# Altium schematic sheet 06 ETH部分.SchDoc("06 ETH部分")
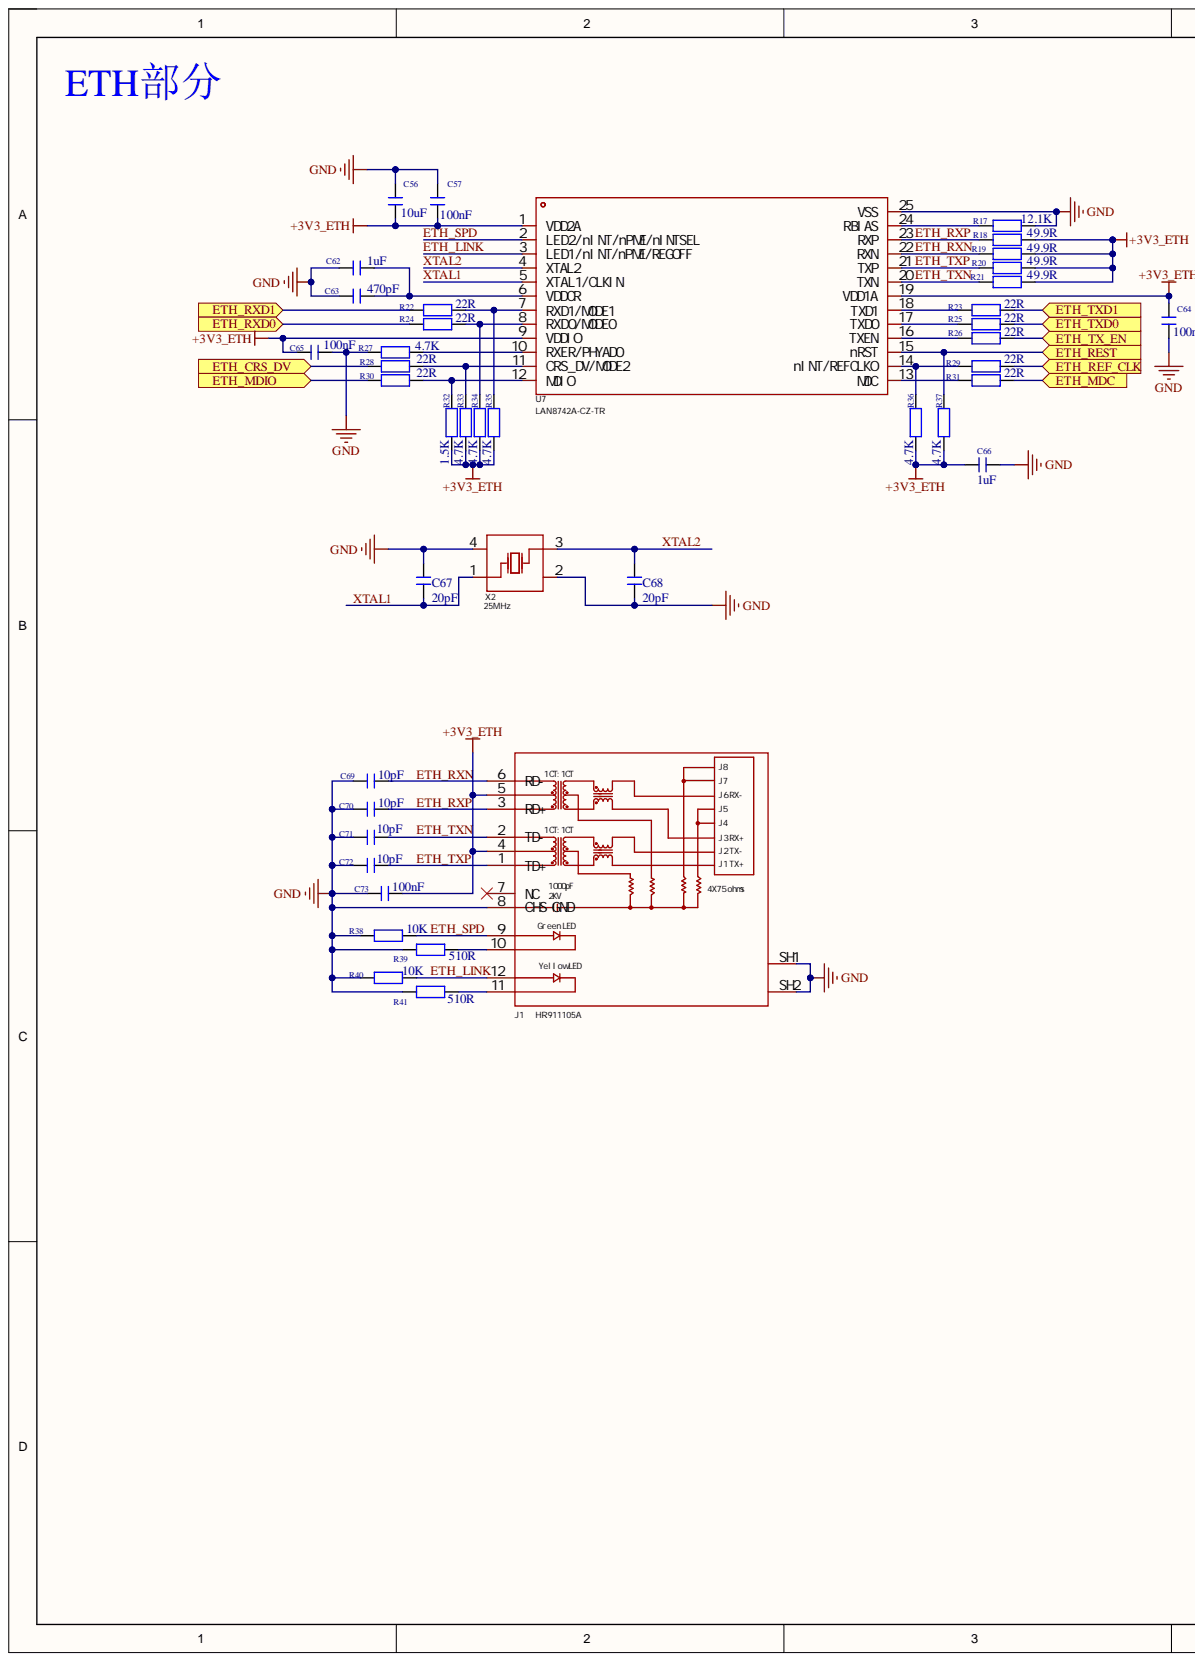
[diagram p0: 06 ETH部分.SchDoc, left]
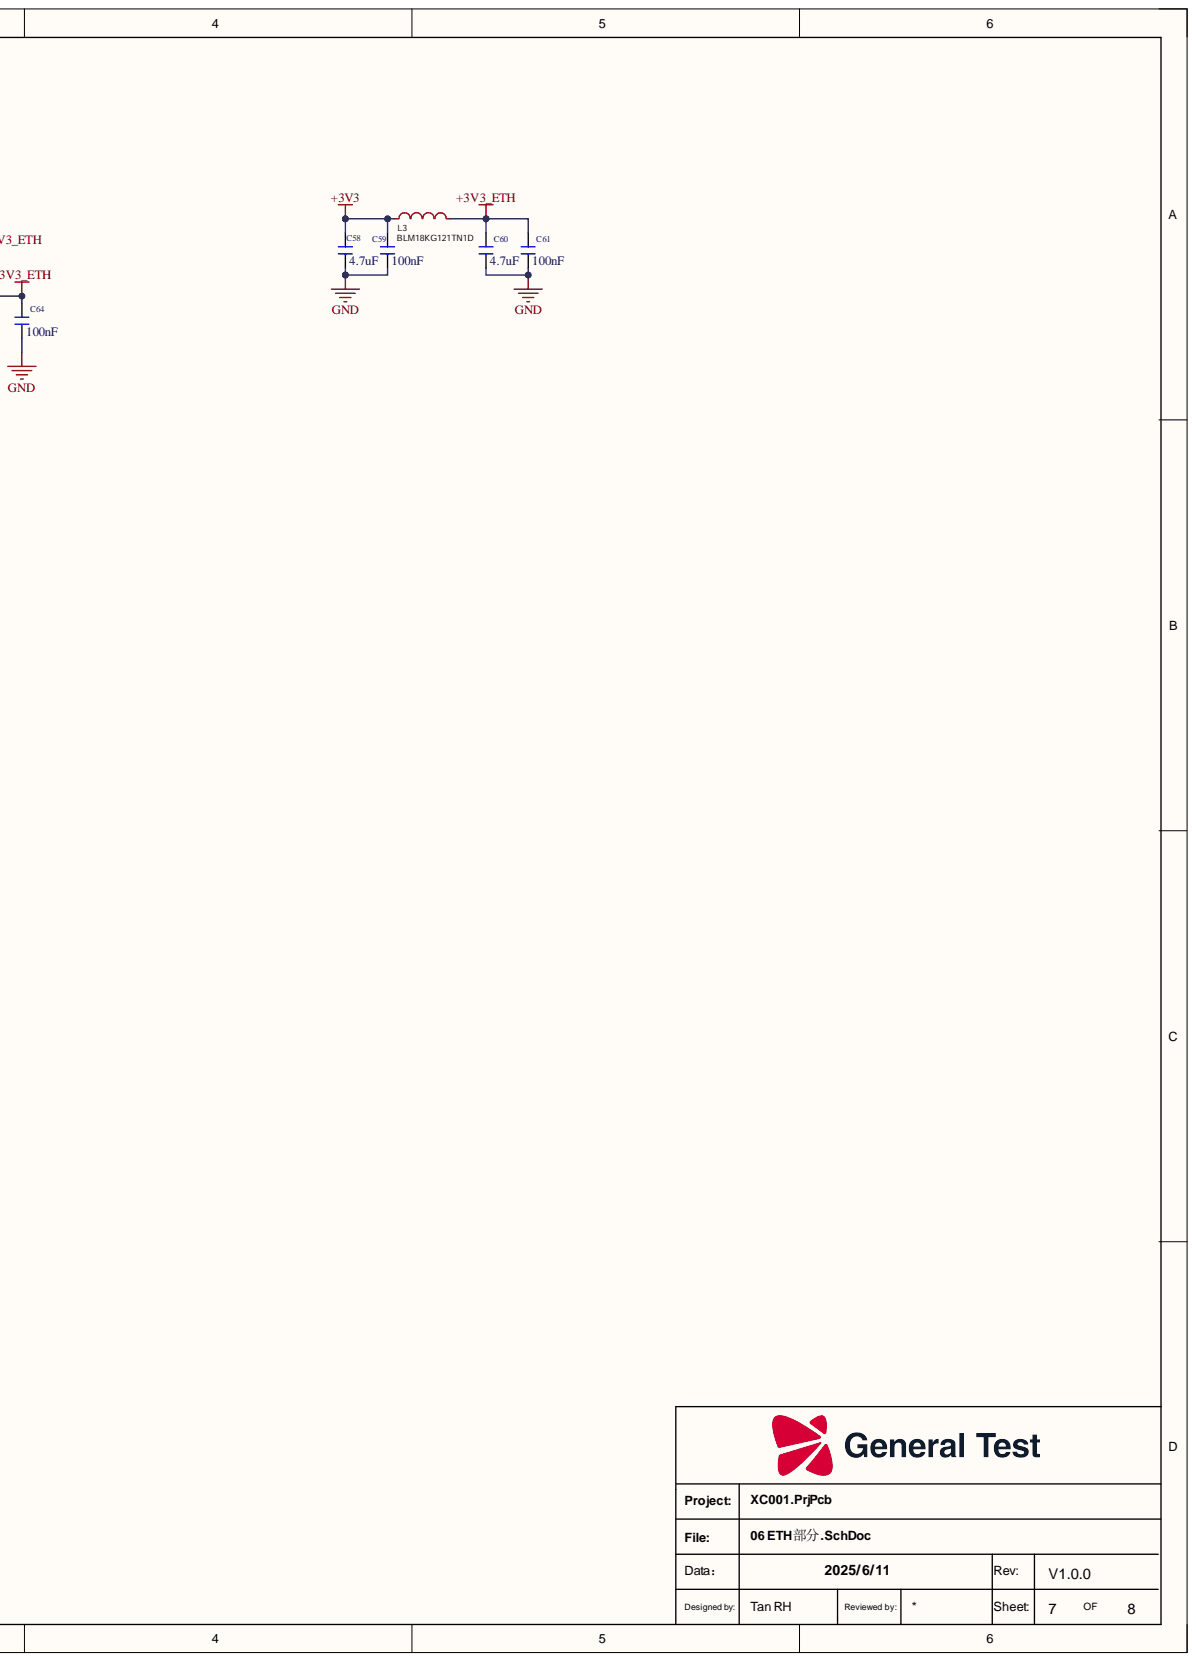
[diagram p1: 06 ETH部分.SchDoc, right]

## Parts
C56  10uF  [C0402_L]
C57  100nF  [C0402_L]
C58  4.7uF  [C0402_L]
C59  100nF  [C0402_L]
C60  4.7uF  [C0402_L]
C61  100nF  [C0402_L]
C62  1uF  [C0402_L]
C63  470pF  [C0402_L]
C64  100nF  [C0402_L]
C65  100nF  [C0402_L]
C66  1uF  [C0402_L]
C67  20pF  [C0402_L]
C68  20pF  [C0402_L]
C69  10pF  [C0402_L]
C70  10pF  [C0402_L]
C71  10pF  [C0402_L]
C72  10pF  [C0402_L]
C73  100nF  [C0603_L]
J1  HR911105A  [RJ45-TH_HR911105A]
L3  BLM18KG121TN1D  [BLM18EG101TH1D]
R17  12.1K  [R0402_L]
R18  49.9R  [R0402_L]
R19  49.9R  [R0402_L]
R20  49.9R  [R0402_L]
R21  49.9R  [R0402_L]
R22  22R  [R0402_L]
R23  22R  [R0402_L]
R24  22R  [R0402_L]
R25  22R  [R0402_L]
R26  22R  [R0402_L]
R27  4.7K  [R0402_L]
R28  22R  [R0402_L]
R29  22R  [R0402_L]
R30  22R  [R0402_L]
R31  22R  [R0402_L]
R32  1.5K  [R0402_L]
R33  4.7K  [R0402_L]
R34  4.7K  [R0402_L]
R35  4.7K  [R0402_L]
R36  4.7K  [R0402_L]
R37  4.7K  [R0402_L]
R38  10K  [R0402_L]
R39  510R  [R0402_L]
R40  10K  [R0402_L]
R41  510R  [R0402_L]
U7  LAN8742A-CZ-TR  [QFN-24_L4.0-W4.0-P0.50-TL-EP2.5]
X2  25MHz  [CRYSTAL-SMD_4P-L3.2-W2.5-BL-2]

## Ports
ETH_CRS_DV, ETH_MDC, ETH_MDIO, ETH_REF_CLK, ETH_REST, ETH_RXD0, ETH_RXD1, ETH_TX_EN, ETH_TXD0, ETH_TXD1

## Nets
+3V3: C58.2 C59.2 L3.1
+3V3_ETH: C56.2 C57.2 C60.2 C61.2 C64.2 C65.1 C66.1 C73.1 J1.4 J1.5 L3.2 R18.2 R19.2 R20.2 R21.2 R32.1 R33.1 R34.1 R35.1 R36.1 R37.1 U7.1(VDD2A) U7.9(VDDIO) U7.19(VDD1A)
GND: C56.1 C57.1 C58.1 C59.1 C60.1 C61.1 C62.1 C63.1 C64.1 C65.2 C66.2 C67.1 C68.2 C69.2 C70.2 C71.2 C72.2 C73.2 J1.8(CHS_GND) J1.SH1 J1.SH2 R17.2 R27.1 R38.1 R39.1 R40.1 R41.1 U7.25(VSS) X2.2 X2.4
ETH_CRS_DV: R28.1 ; port ETH_CRS_DV
ETH_LINK: J1.12 R40.2 U7.3(LED1/nINT/nPME/REGOFF)
ETH_MDC: R31.2 ; port ETH_MDC
ETH_MDIO: R30.1 ; port ETH_MDIO
ETH_REF_CLK: R29.2 ; port ETH_REF_CLK
ETH_REST: R37.2 U7.15(nRST) ; port ETH_REST
ETH_RXD0: R24.1 ; port ETH_RXD0
ETH_RXD1: R22.1 ; port ETH_RXD1
ETH_RXN: C69.1 J1.6(RD-) R19.1 U7.22(RXN)
ETH_RXP: C70.1 J1.3(RD+) R18.1 U7.23(RXP)
ETH_SPD: J1.9 R38.2 U7.2(LED2/nINT/nPME/nINTSEL)
ETH_TX_EN: R26.2 ; port ETH_TX_EN
ETH_TXD0: R25.2 ; port ETH_TXD0
ETH_TXD1: R23.2 ; port ETH_TXD1
ETH_TXN: C71.1 J1.2(TD-) R21.1 U7.20(TXN)
ETH_TXP: C72.1 J1.1(TD+) R20.1 U7.21(TXP)
XTAL1: C67.2 U7.5(XTAL1/CLKIN) X2.1
XTAL2: C68.1 U7.4(XTAL2) X2.3
NetC62_2: C62.2 C63.2 U7.6(VDDCR)
NetJ1_10: J1.10 R39.2
NetJ1_11: J1.11 R41.2
NetR17_1: R17.1 U7.24(RBIAS)
NetR22_2: R22.2 R35.2 U7.7(RXD1/MODE1)
NetR23_1: R23.1 U7.18(TXD1)
NetR24_2: R24.2 R34.2 U7.8(RXD0/MODE0)
NetR25_1: R25.1 U7.17(TXD0)
NetR26_1: R26.1 U7.16(TXEN)
NetR27_2: R27.2 U7.10(RXER/PHYAD0)
NetR28_2: R28.2 R33.2 U7.11(CRS_DV/MODE2)
NetR29_1: R29.1 R36.2 U7.14(nINT/REFCLKO)
NetR30_2: R30.2 R32.2 U7.12(MDIO)
NetR31_1: R31.1 U7.13(MDC)

Not connected: J1 7(NC)

## Library data of the parts
C56-C66 C69-C73 = C: 贴片电容; BOM名称: 无极性贴片电容; 淘宝ID: 封装库来自淘宝 ID：黄晶宝石; Component Kind: Standard; Library Name: 0.1 - 电阻-电容-电感.SchLib; 唯一出售店铺网址: https://shop463601444.taobao.com; 联系售后，技术支持: https://shop463601444.taobao.com
C67 C68 = C: 贴片电容; BOM名称: 无极性贴片电容; LibRef: GRM1555C1H180FA01D; 淘宝ID: 封装库来自淘宝 ID：黄晶宝石; Component Kind: Standard; Library Name: 0.1 - 电阻-电容-电感.SchLib; 唯一出售店铺网址: https://shop463601444.taobao.com; 联系售后，技术支持: https://shop463601444.taobao.com
J1 = HR911105A_C12074: Manufacturer: HANRUN(汉仁); Add into BOM: yes; Connector Type: RJ45插座; LED: 带LED; Number of Ports: 1; PoE: 不带PoE; Supplementary Features: 带滤波; Component Kind: Standard; Contact Material: -; Contact Plating: -; Convert to PCB: yes; Datasheet: https://atta.szlcsc.com/upload/public/pdf/source/20170918/C12074_1505726819496976146.pdf; Device: HR911105A_C12074; JLCPCB Part Class: Extended Part; LCSC Part Name: 带LED; Library Name: Stm32h743 Pcb.SchLib; Manufacturer Part: HR911105A; Mounting Style: -; Operating Temperature Range: -; PCB3D: RJ45-TH_HR911105A; Ratings: -; Shielding: -; Supplier: LCSC; Supplier Footprint: 插件; Supplier Part: C12074; Symbol: HR911105A_C12074
L3 = BLM18KG121TN1D: 120Ω@100MHz; Manufacturer: muRata(村田); Add into BOM: yes; Circuits: 1; Current Rating: 3A; DC Resistance: 30mΩ; Tolerance: ±25%; Component Kind: Standard; Convert to PCB: yes; Datasheet: https://atta.szlcsc.com/upload/public/pdf/source/20180329/C160981_FD3C2D2622A377E203B89F4AA32B0741.pdf; Device: BLM18KG121TN1D; Impedance @ Frequency: 120Ω@100MHz; JLCPCB Part Class: Extended Part; LCSC Part Name: 单路 0603磁珠 阻抗120Ω@100MHz; Library Name: Schlib1.SchLib; Manufacturer Part: BLM18KG121TN1D; Supplier: LCSC; Supplier Footprint: 0603; Supplier Part: C85831; Symbol: BLM18KG121TN1D
R17-R41 = R: 贴片电阻; 封装库来自淘宝卖家 ID：黄晶宝石: 其他店铺购入均为盗版，不享受售后技术支持服务，请立即申请退款; 版权归属: 来自淘宝 ID：黄晶宝石; BOM名称: 贴片电阻; Component Kind: Standard; Library Name: 0.1 - 电阻-电容-电感.SchLib; 联系售后: https://item.taobao.com/item.htm?id=566775463719
U7 = LAN8742A-CZ-TR: Manufacturer: MICROCHIP(美国微芯); Add into BOM: yes; Interface: RMII; Number of Channels: 1; Voltage - Supply: 1.8V~3.3V; Applications Function: -; Component Kind: Standard; Convert to PCB: yes; Datasheet: https://atta.szlcsc.com/upload/public/pdf/source/20201120/C621424_261C8C55AFDB52EE903AE3A1A642D6DC.pdf; Device: LAN8742A-CZ-TR; JLCPCB Part Class: Extended Part; LCSC Part Name: LAN8742A/LAN8742Ai 小型RMII 10/100以太网收发器带HP功能; Library Name: Stm32h743 Pcb.SchLib; Manufacturer Part: LAN8742A-CZ-TR; Operating Temperature: 0℃~+70℃; PCB3D: QFN-24_L4.0-W4.0-P0.50-TL-EP2.1; Supplier: LCSC; Supplier Footprint: QFN-24-EP(4x4); Supplier Part: C621424; Symbol: LAN8742A-CZ-TR
X2 = X322525MSB4SI: Manufacturer: YXC(扬兴晶振); Add into BOM: yes; Crystal Type: 贴片晶振; Frequency Stability(Full temperature range): ±20ppm; Load Capacitor: 20pF; Normal temperature Frequency Tolerance: ±10ppm; Component Kind: Standard; Convert to PCB: yes; Datasheet: https://atta.szlcsc.com/upload/public/pdf/source/20240329/19D5F850207469550BEB00D7DFEDBC7A.pdf; Device: X322525MSB4SI; JLCPCB Part Class: Extended Part; LCSC Part Name: 25MHz ±10ppm 20pF; Library Name: Stm32h743 Pcb.SchLib; Manufacturer Part: X322525MSB4SI; Operating Temperature: -40℃~+85℃; Supplier: LCSC; Supplier Footprint: SMD3225-4P; Supplier Part: C13740; Symbol: X322525MSB4SI; Value: 25MHz
